# Altium schematic sheet power_management.SchDoc
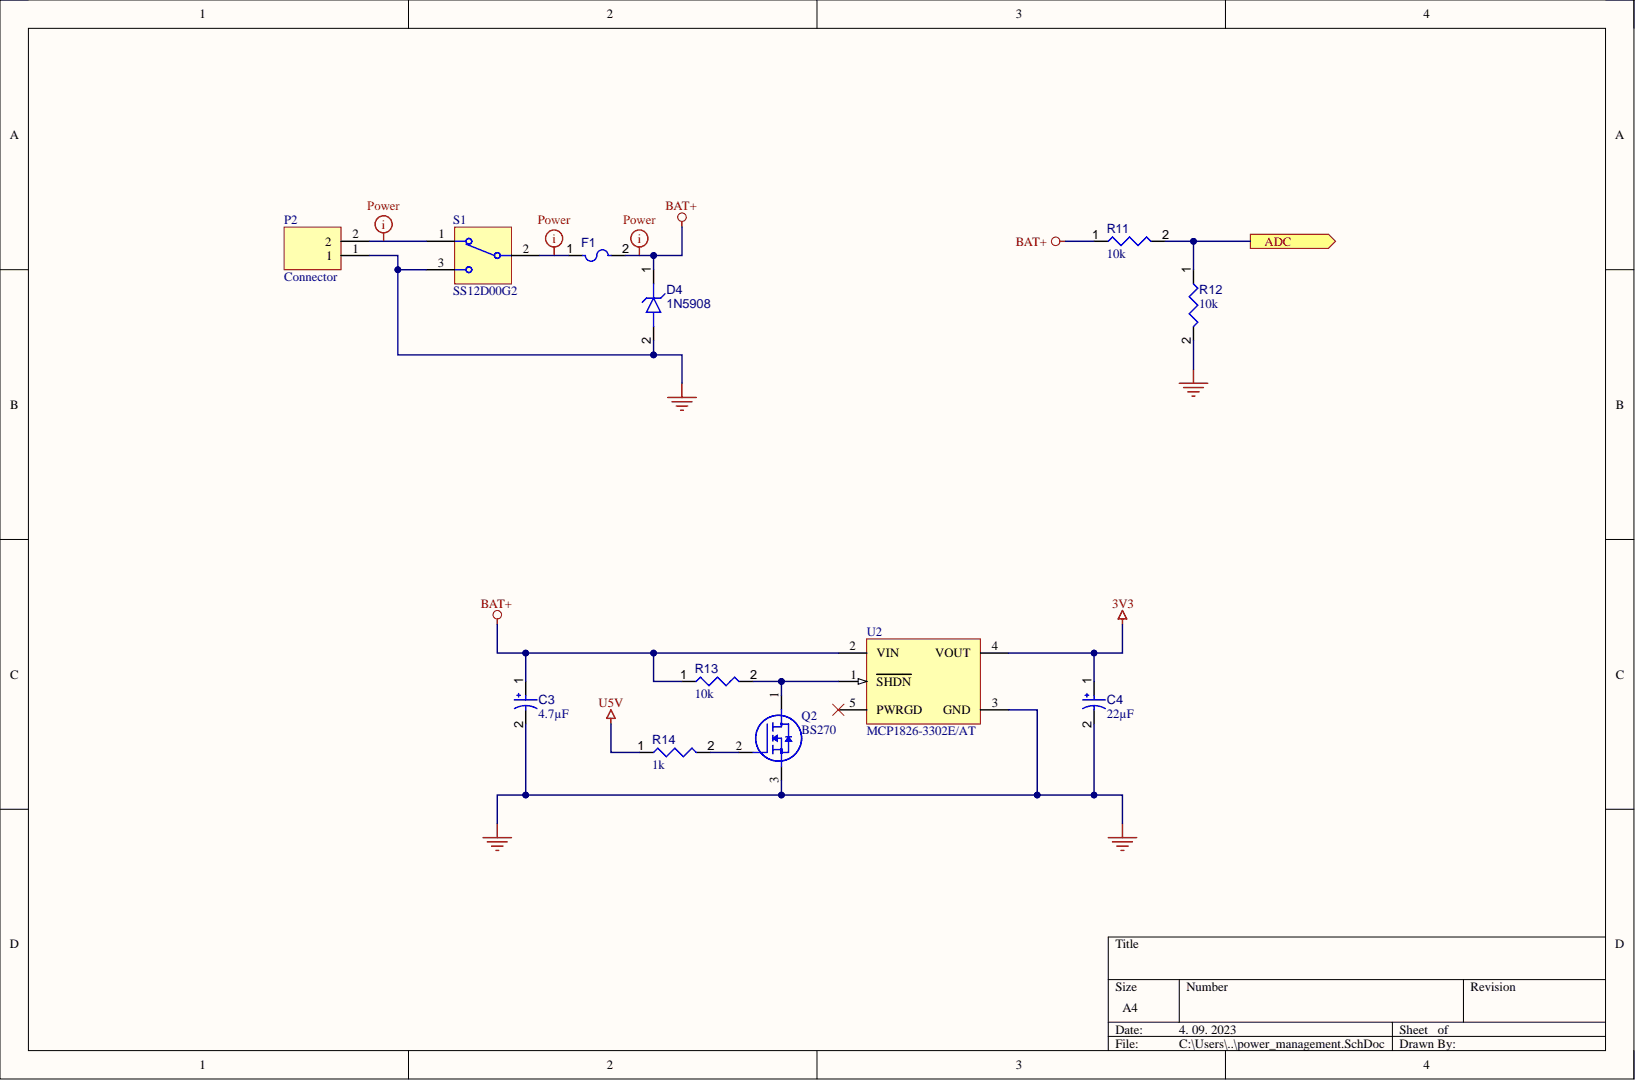
[diagram p0: power_management.SchDoc]

## Parts
C3  value 4.7µF  [CAPPR55-200-1100X500X1200]  (hidden comment: ECA1HM4R7)
C4  value 22µF  [CAPPR55-250-1120X630X1220]  (hidden comment: ECA-2AM220)
D4  1N5908  [Transil Diode]
F1  POLYFUSE BU110  [Radial Leaded PTC]  (value not drawn)
P2  Connector  [FP_CONN2_TUSKE_254MM]
Q2  BS270  [BS270-Footprint-1]
R11  value 10k  [RESA60-630X240]  (hidden comment: MFR-25FBF52-10K)
R12  value 10k  [RESA60-630X240]  (hidden comment: MFR-25FBF52-10K)
R13  value 10k  [RESA60-630X240]  (hidden comment: MFR-25FBF52-10K)
R14  value 1k  [RESA60-630X240]  (hidden comment: MFR-25FBF52-10K)
S1  SS12D00G2  [SS12D00G2-Footprint-1]
U2  MCP1826-3302E/AT  [TO-220-AT5]

## Ports
ADC

## Nets
3V3: C4.1 U2.4(VOUT)
BAT+: C3.1 D4.1 F1.2 R11.1 R13.1 U2.2(VIN)
GND: C3.2 C4.2 D4.2 P2.1 Q2.3 R12.2 S1.3 U2.3(GND)
U5V: R14.1
ADC: R11.2 R12.1 ; port ADC
NetF1_1: F1.1 S1.2
NetP2_2: P2.2 S1.1
NetQ2_1: Q2.1 R13.2 U2.1(SHDN)
NetQ2_2: Q2.2 R14.2

Not connected: U2 5(PWRGD)

## Library data of the parts
C3 = CMP-001-00033-7: Aluminum Electrolytic Capacitor, 4.7 uF, +/- 20%, 50 V, -40 to 85 degC, 2-Pin THD, RoHS, Bulk; Manufacturer: Panasonic; Ripple Current: 45mA; Voltage Rating: 50V; Capacitor Type: Electrolytic; Case/Package: Radial; Component Kind: Standard; Datasheet URL: https://industrial.panasonic.com/cdbs/www-data/pdf/RDF0000/ABA0000C1218.pdf; Datasheet Version: Rev. 05, 10/2016; Diameter: 5mm; Lead Pitch: 2mm; Manufacturer URL: http://www.panasonic.com/; Max Operating Temperature: 85°C; Min Operating Temperature: -40°C; Mounting Technology: TH; Package Description: 2-Pin Radial Lead, Dia 5 mm, Height 12 mm; Package Quantity: 200; Package Reference: CAPPR55-200-1100X500X1200; Packaging: Bulk; Pins: 2; Ripple Current (AC): 45mA; RoHS Compliant: True; Tolerance: 20%; Library Name: Budapest University of Technology and Economics
C4 = CMP-001-00035-7: Aluminum Electrolytic Capacitor, 22 uF, +/- 20%, 100 V, -40 to 85 degC, 2-Pin THD, RoHS, Bulk; Manufacturer: Panasonic; Ripple Current: 115mA; Voltage Rating: 100V; Capacitor Type: Electrolytic; Case/Package: Radial; Component Kind: Standard; Datasheet URL: https://industrial.panasonic.com/cdbs/www-data/pdf/RDF0000/ABA0000C1047.pdf; Datasheet Version: Mar-16; Diameter: 6.3mm; Lead Pitch: 2.5mm; Manufacturer URL: http://www.panasonic.com/; Max Operating Temperature: 85°C; Min Operating Temperature: -40°C; Mounting Technology: TH; Package Description: 2-Pin Radial Lead, Dia 6.3 mm, Height 12.2 mm; Package Quantity: 200; Package Reference: CAPPR55-250-1120X630X1220; Packaging: Bulk; Pins: 2; Ripple Current (AC): 115mA; RoHS Compliant: True; Tolerance: 20%; Library Name: Budapest University of Technology and Economics
D4 = CMP-004-00033-1: 1N5908 Series 1500 W 6 V Uni Directional TVS Diode - DO-201; Max Surge Current: 120A; Case/Package: DO-201; Component Kind: Standard; Max Operating Temperature: 175°C; Min Operating Temperature: -55°C; Mounting Technology: Through Hole; Pins: 2; Library Name: Budapest University of Technology and Economics
F1 = CMP-005-00014-1: Radial leaded PTC, 700mW, 1.1A, THT; Component Kind: Standard; Current Rating: 1.1A; Max Operating Temperature: 85°C; Min Operating Temperature: -45°C; Mounting Technology: THT; Pins: 2; Library Name: Budapest University of Technology and Economics
P2 = Connector_2pin: 2pin connector; Component Kind: Standard; Library Name: hallglib.SchLib
Q2 = CMP-013-00041-2: N-Channel MOSFET, Enhancement Mode, 60V, 400mA, 2Ω; Nominal Vgs: 2.1V; Case/Package: TO-92; Component Kind: Standard; Max Operating Temperature: 150°C; Min Operating Temperature: -55°C; Mounting Technology: Through Hole; Pins: 3; RoHS Compliant: Yes; Library Name: Budapest University of Technology and Economics
R11-R14 = CMP-009-00047-4: Axial Resistor, 10 KOhm, +/- 1%, 0.25 W, -55 to 155 degC, 2-Pin THD, RoHS, Bulk; Manufacturer: Yageo; Power: 250mW; Voltage Rating: 250V; Case/Package: Axial; Component Kind: Standard; Datasheet URL: http://www.yageo.com/documents/recent/Yageo%20LR_MFR_2013.pdf; Datasheet Version: Apr-13; Manufacturer URL: http://www.yageo.com/; Max Operating Temperature: 155°C; Min Operating Temperature: -55°C; Mounting Technology: TH; Package Description: 2-Pin Axial Lead, Dia 2.4 mm, Body Length 6.3 mm; Package Quantity: 200; Package Reference: MFR-25; Packaging: Bulk; RoHS Compliant: True; Tolerance: 1%; Library Name: Budapest University of Technology and Economics
S1 = CMP-011-00013-1: Two state slide switch, ON-ON, SPDT; Component Kind: Standard; Mounting Technology: Through Hole; Pins: 3; Library Name: Budapest University of Technology and Economics
U2 = CMP-019-00050-1: IC; Linear Regulator; Max. Input; 6V; Output; 3.3V; 1000MA; Accuracy; 0.5%; TO220-5; Component Kind: Standard; Library Name: Budapest University of Technology and Economics
